# Altium schematic sheet Current_Measurement_CD.SchDoc(Current_Measurement_CD)
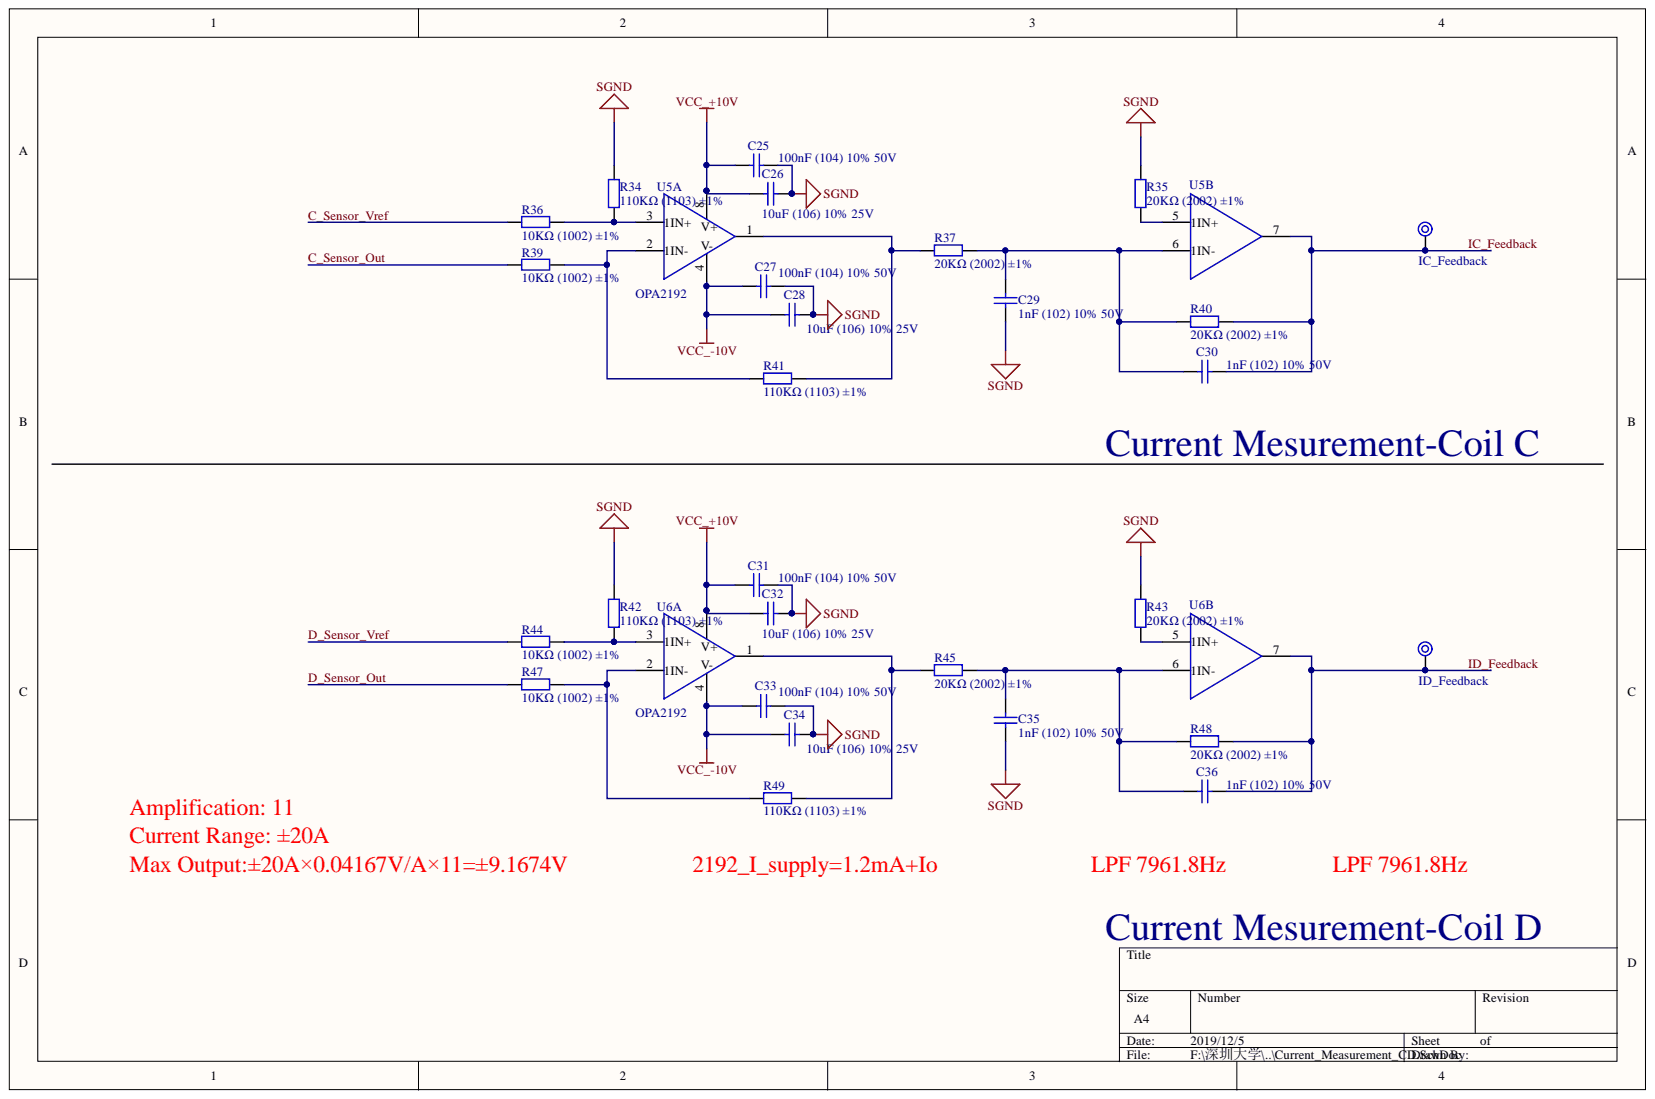
[diagram p0: Current_Measurement_CD.SchDoc]

## Parts
C25  100nF (104) 10% 50V  [0603_C]
C26  10uF (106) 10% 25V  [0805_C]
C27  100nF (104) 10% 50V  [0603_C]
C28  10uF (106) 10% 25V  [0805_C]
C29  1nF (102) 10% 50V  [0603_C]
C30  1nF (102) 10% 50V  [0603_C]
C31  100nF (104) 10% 50V  [0603_C]
C32  10uF (106) 10% 25V  [0805_C]
C33  100nF (104) 10% 50V  [0603_C]
C34  10uF (106) 10% 25V  [0805_C]
C35  1nF (102) 10% 50V  [0603_C]
C36  1nF (102) 10% 50V  [0603_C]
IC_Feedback  TP_Surface  [TEST_POINT_ME]  (value not drawn)
ID_Feedback  TP_Surface  [TEST_POINT_ME]  (value not drawn)
R34  110KΩ (1103) ±1%  [0603_R]
R35  20KΩ (2002) ±1%  [0603_R]
R36  10KΩ (1002) ±1%  [0603_R]
R37  20KΩ (2002) ±1%  [0603_R]
R39  10KΩ (1002) ±1%  [0603_R]
R40  20KΩ (2002) ±1%  [0603_R]
R41  110KΩ (1103) ±1%  [0603_R]
R42  110KΩ (1103) ±1%  [0603_R]
R43  20KΩ (2002) ±1%  [0603_R]
R44  10KΩ (1002) ±1%  [0603_R]
R45  20KΩ (2002) ±1%  [0603_R]
R47  10KΩ (1002) ±1%  [0603_R]
R48  20KΩ (2002) ±1%  [0603_R]
R49  110KΩ (1103) ±1%  [0603_R]
U5  OPA2192  [SOIC127P600X175-8N]  (parts A,B)
U6  OPA2192  [SOIC127P600X175-8N]  (parts A,B)

## Nets
SGND: C25.2 C26.2 C27.2 C28.2 C29.1 C31.2 C32.2 C33.2 C34.2 C35.1 R34.2 R35.2 R42.2 R43.2
VCC_+10V: C25.1 C26.1 C31.1 C32.1 U5.8(V+) U6.8(V+)
VCC_-10V: C27.1 C28.1 C33.1 C34.1 U5.4(V-) U6.4(V-)
C_Sensor_Out: R39.1
C_Sensor_Vref: R36.1
D_Sensor_Out: R47.1
D_Sensor_Vref: R44.1
IC_Feedback: C30.2 IC_Feedback.1 R40.2 U5.7
ID_Feedback: C36.2 ID_Feedback.1 R48.2 U6.7
NetC29_2: C29.2 C30.1 R37.2 R40.1 U5.6(1IN-)
NetC35_2: C35.2 C36.1 R45.2 R48.1 U6.6(1IN-)
NetR34_1: R34.1 R36.2 U5.3(1IN+)
NetR35_1: R35.1 U5.5(1IN+)
NetR37_1: R37.1 R41.2 U5.1
NetR39_2: R39.2 R41.1 U5.2(1IN-)
NetR42_1: R42.1 R44.2 U6.3(1IN+)
NetR43_1: R43.1 U6.5(1IN+)
NetR45_1: R45.1 R49.2 U6.1
NetR47_2: R47.2 R49.1 U6.2(1IN-)

## Library data of the parts
C25 C27 C29-C31 C33 C35 C36 = 0603 100nF (104) 10% 50V: 贴片电容; SuppliersPartNumber: C14663; Supplier: LC; Package: 0603; Library Name: JLC Library.IntLib; ComponentLink1URL: http://www.szlcsc.com/product/details_15331.html; ComponentLink1Description: 供应商链接; Component Kind: Standard
C26 C28 C32 C34 = 0805 10uF (106) 10% 25V: 贴片电容; SuppliersPartNumber: C15850; Supplier: LC; Package: 0805; Library Name: JLC Library.IntLib; ComponentLink1URL: http://www.szlcsc.com/product/details_16532.html; ComponentLink1Description: 供应商链接; Component Kind: Standard
R34 R41 R42 R49 = 0603 110KΩ (1103) 1%: 贴片电阻; SuppliersPartNumber: C25805; Supplier: LC; Package: 0603; Library Name: JLC Library.IntLib; ComponentLink1URL: http://www.szlcsc.com/product/details_26548.html; ComponentLink1Description: 供应商链接; Component Kind: Standard
R35 R37 R40 R43 R45 R48 = 0603 20KΩ (2002) 1%: 贴片电阻; SuppliersPartNumber: C4184; Supplier: LC; Package: 0603; Library Name: JLC Library.IntLib; ComponentLink1URL: http://www.szlcsc.com/product/details_4591.html; ComponentLink1Description: 供应商链接; Component Kind: Standard
R36 R39 R44 R47 = 0603 10KΩ (1002) 1%: 贴片电阻; SuppliersPartNumber: C25804; Supplier: LC; Package: 0603; Library Name: JLC Library.IntLib; ComponentLink1URL: http://www.szlcsc.com/product/details_26547.html; ComponentLink1Description: 供应商链接; Component Kind: Standard
U5 U6 = OPA2192: 精密运放; Component Kind: Standard; Library Name: PSRM_Sensorless_V2.0模块.IntLib
IC_Feedback ID_Feedback = TP_Surface: Component Kind: Standard; Library Name: PSRM_Sensorless_V2.0模块.IntLib
